# Altium schematic sheet Airlock_Motor.SchDoc(Airlock_Motor)
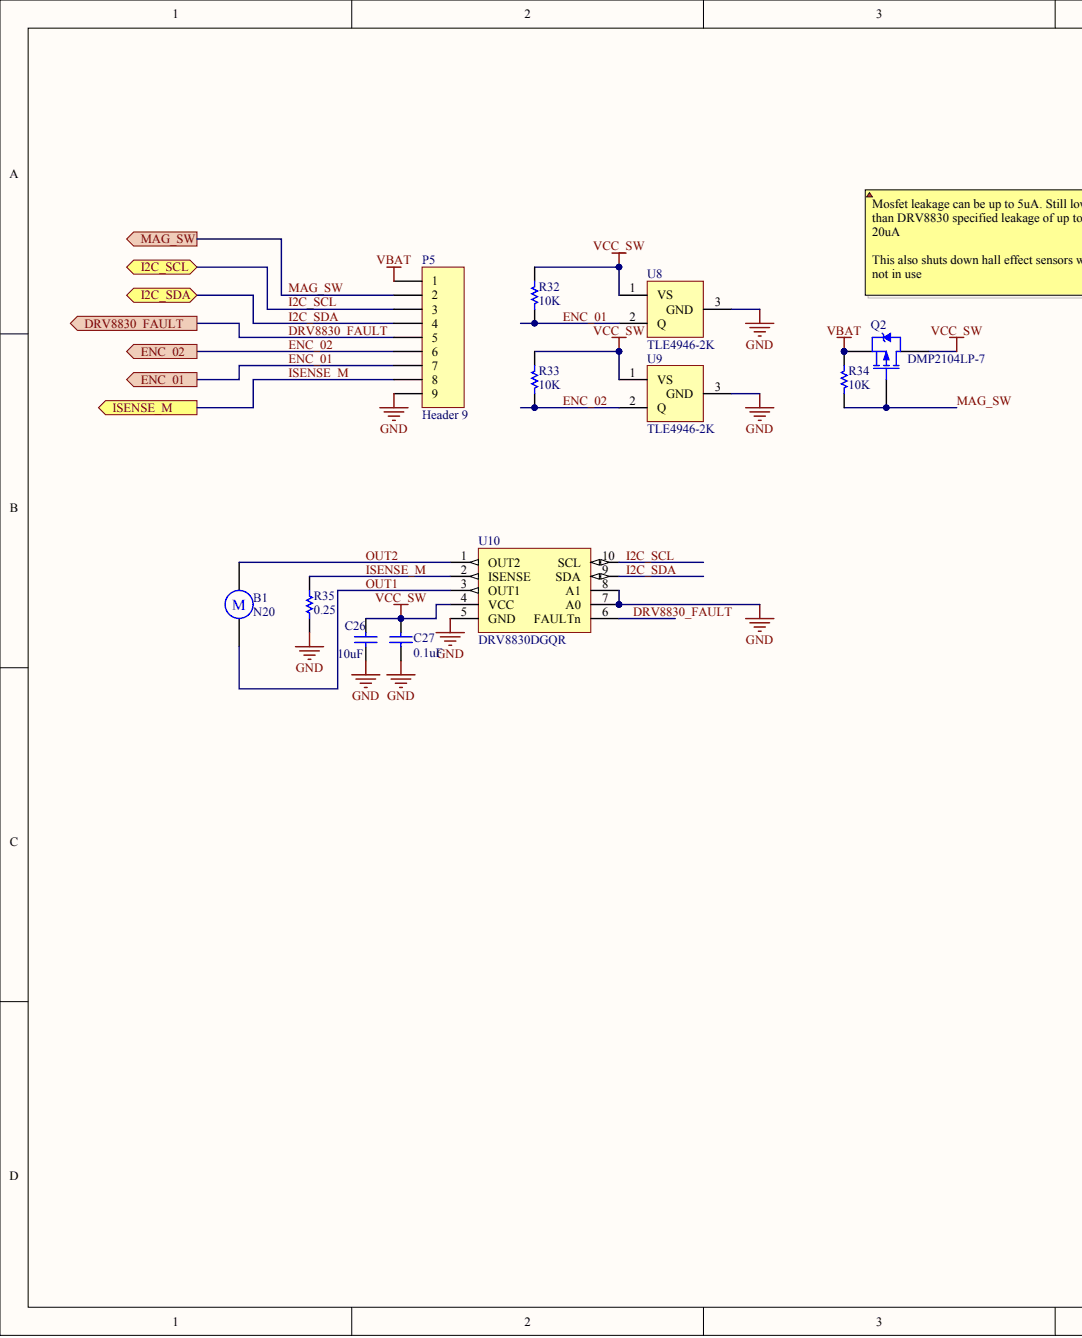
[diagram p0: Airlock_Motor.SchDoc, left]
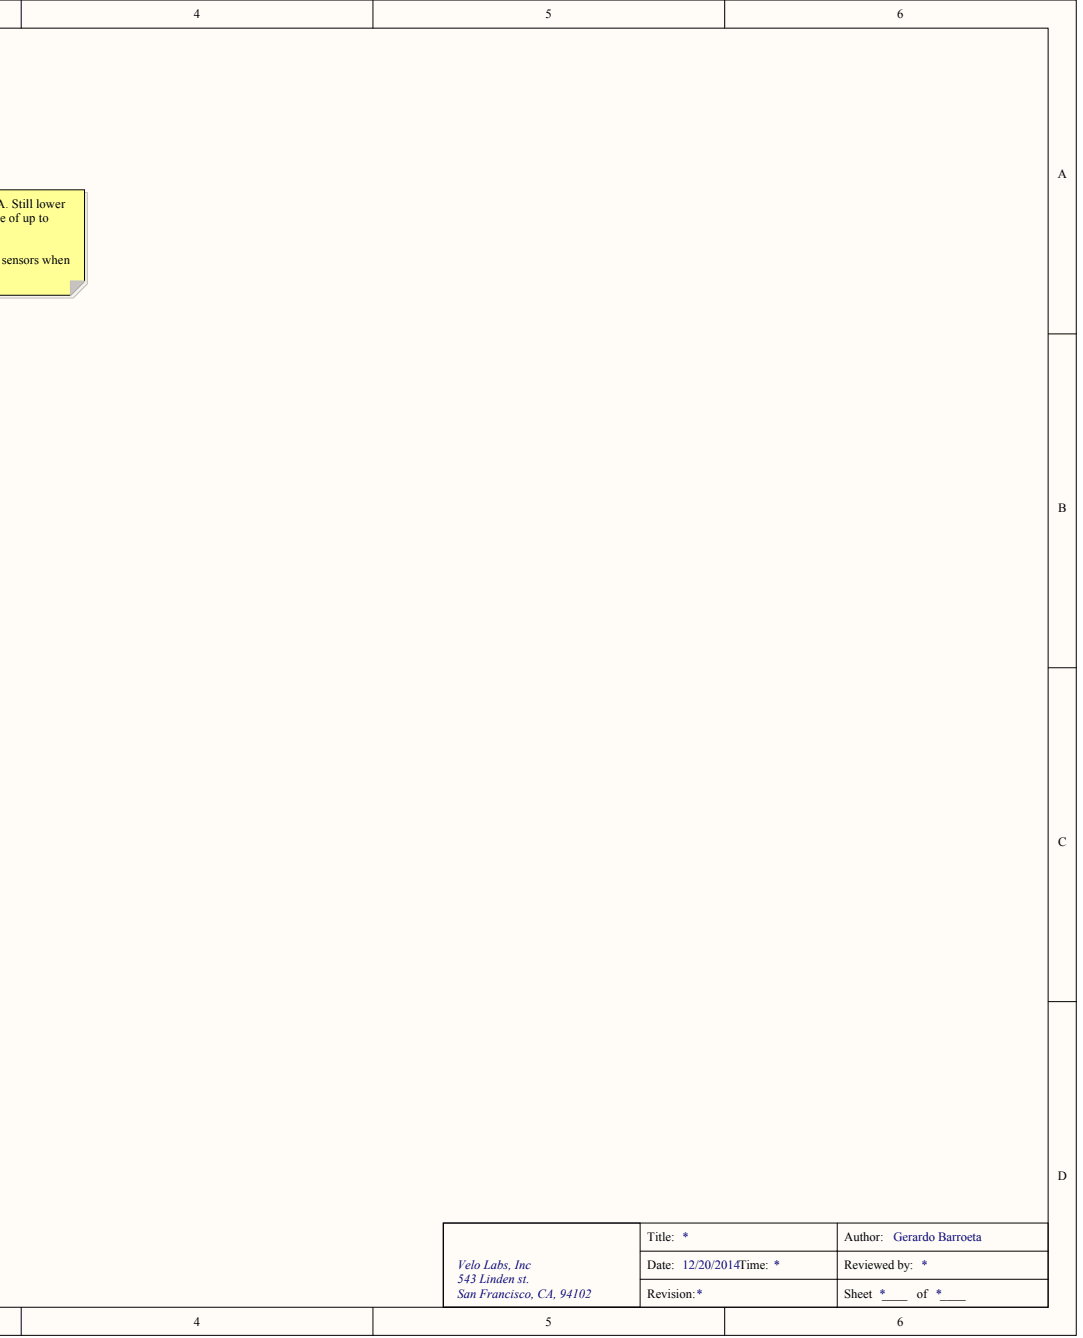
[diagram p1: Airlock_Motor.SchDoc, right]

## Parts
B1  N20
C26  value 10uF  (hidden comment: X5R, 6.3V, 20%)
C27  value 0.1uF  (hidden comment: X7R, 16V, 10%)
P5  Header 9
Q2  DMP2104LP-7
R32  1%  value 10K
R33  1%  value 10K
R34  1%  value 10K
R35  value 0.25  (hidden comment: 1%)
U8  TLE4946-2K
U9  TLE4946-2K
U10  DRV8830DGQR

## Ports
DRV8830_FAULT, ENC_01, ENC_02, I2C_SCL, I2C_SDA, ISENSE_M, MAG_SW

## Nets
GND: C26.1 C27.2 P5.9 R35.2 U8.3 U9.3 U10.0 U10.5(GND) U10.7(A0) U10.8(A1)
VBAT: P5.1 Q2.2 R34.2
VCC_SW: C26.2 C27.1 Q2.3 R32.2 R33.2 U8.1 U9.1 U10.4(VCC)
DRV8830_FAULT: P5.5 U10.6(FAULTn) ; port DRV8830_FAULT
ENC_01: P5.7 R32.1 U8.2 ; port ENC_01
ENC_02: P5.6 R33.1 U9.2 ; port ENC_02
I2C_SCL: P5.3 U10.10(SCL) ; port I2C_SCL
I2C_SDA: P5.4 U10.9(SDA) ; port I2C_SDA
ISENSE_M: P5.8 R35.1 U10.2(ISENSE) ; port ISENSE_M
MAG_SW: P5.2 Q2.1 R34.1 ; port MAG_SW
OUT1: B1.2 U10.3(OUT1)
OUT2: B1.1 U10.1(OUT2)

## Library data of the parts
C26 = (no symbol name): Manufacturer: Taiyo Yuden; Manufacturer Part Number: JMK107ABJ106MAHT; Capacitance: 10µF; Description 1: CAP CER 10UF 6.3V 20% X5R 0603; Supplier 1: Digi-Key; Supplier Part Number 1: 587-3383-1-ND; RoHS: RoHS Compliant; Category: Capacitors; Stock 1: 338432; Pricing 1: 1=0.32, 10=0.21, 50=0.109, 100=0.084, 250=0.06036, 500=0.04306, 1000=0.0315 (USD); Packaging: Cut Tape (CT); Tolerance: ±20%; Voltage - Rated: 6.3V; Temperature Coefficient: X5R; Mounting Type: Surface Mount, MLCC; Operating Temperature: -55°C ~ 85°C; Applications: Automotive; Ratings: AEC-Q200; Package / Case: 0603 (1608 Metric); Size / Dimension: 0.063" L x 0.031" W (1.60mm x 0.80mm); Height - Seated (Max): -; Thickness (Max): 0.035" (0.90mm); Lead Spacing: -; Features: -; Lead Style: -; ComponentLink1URL: http://www.yuden.co.jp/productdata/catalog/en/mlcc01_hq_e.pdf; ComponentLink1Description: http://www.yuden.co.jp/productdata/catalog/en/mlcc01_hq_e.pdf
C27 = (no symbol name): Manufacturer: Murata Electronics North America; Manufacturer Part Number: GRM155R71C104KA88D; Description 1: CAP CER 0.1UF 16V 10% X7R 0402; Capacitance: 0.1µF; Supplier 1: Digi-Key; Supplier Part Number 1: 490-3261-1-ND; RoHS: RoHS Compliant; Category: Capacitors; Stock 1: 16410340; Pricing 1: 1=0.1, 10=0.015, 50=0.0082, 100=0.0069, 250=0.00564, 500=0.00482, 1000=0.00375, 5000=0.00313 (USD); Packaging: Cut Tape (CT); Tolerance: ±10%; Voltage - Rated: 16V; Temperature Coefficient: X7R; Mounting Type: Surface Mount, MLCC; Operating Temperature: -55°C ~ 125°C; Applications: General Purpose; Ratings: -; Package / Case: 0402 (1005 Metric); Size / Dimension: 0.039" L x 0.020" W (1.00mm x 0.50mm); Height - Seated (Max): -; Thickness (Max): 0.022" (0.55mm); Lead Spacing: -; Features: -; Lead Style: -; ComponentLink1URL: http://www.murata.com/~/media/webrenewal/support/library/catalog/products/capacitor/mlcc/c02e.ashx; ComponentLink1Description: http://www.murata.com/~/media/webrenewal/support/library/catalog/products/capacitor/mlcc/c02e.ashx
Q2 = (no symbol name): Manufacturer: Diodes Inc; Description 1: MOSFET P-CH 20V 1.5A 3-DFN; FET Type: MOSFET P-Channel, Metal Oxide; FET Feature: Logic Level Gate; Drain to Source Voltage (Vdss): 20V; Current - Continuous Drain (Id) @ 25° C: 1.5A (Ta); Rds On (Max) @ Id, Vgs: 150 mOhm @ 950mA, 4.5V; Vgs(th) (Max) @ Id: 1V @ 250µA; Input Capacitance (Ciss) @ Vds: 320pF @ 16V; Power - Max: 500mW; Published: 8-Jun-2000; LatestRevisionDate: 17-Jul-2002; LatestRevisionNote: Re-released for DXP Platform.; PackageVersion: 1995/1996; PackageReference: E3; PackageDescription: TO, Flat Index; 3 In-Line, Axial Leads; Body Dia. 4.6mm; Lead Dia. 0.5 mm (max); Supplier 1: Digi-Key; Manufacturer Part Number: DMP2104LP-7; RoHS: RoHS Compliant; Category: Discrete Semiconductor Products; Stock 1: 8333; Pricing 1: 1=0.44, 10=0.341, 25=0.288, 100=0.2347, 250=0.19436, 500=0.16056, 1000=0.12025 (USD); Packaging: Digi-Reel®; Gate Charge (Qg) @ Vgs: -; Mounting Type: Surface Mount; Package / Case: 3-XDFN; Supplier Device Package: DFN1411-3; ComponentLink1URL: http://www.diodes.com/datasheets/ds31091.pdf; ComponentLink1Description: http://www.diodes.com/datasheets/ds31091.pdf; Supplier Part Number 1: DMP2104LPDIDKR-ND; Publisher: Altium Limited
R32-R34 = (no symbol name): Manufacturer: Panasonic Electronic Components; Manufacturer Part Number: ERJ-2RKF1002X; Description 1: RES SMD 10K OHM 1% 1/10W 0402; Power (Watts): 0.1W, 1/10W; Temperature Coefficient: ±100ppm/°C; Published: 8-Jun-2000; LatestRevisionDate: 17-Jul-2002; LatestRevisionNote: Re-released for DXP Platform.; PackageReference: AXIAL-0.3; PackageDescription: Axial Device, Thru-Hole; 2 Leads; 0.3 in Pin Spacing; Supplier 1: Digi-Key; Supplier Part Number 1: P10.0KLCT-ND; RoHS: RoHS Compliant; Category: Resistors; Stock 1: 185308; Pricing 1: 1=0.1, 50=0.0196, 100=0.0149, 250=0.01104, 500=0.00816, 1000=0.00544, 5000=0.00383 (USD); Packaging: Cut Tape (CT); Resistance (Ohms): 10k; Tolerance: ±1%; Composition: Thick Film; Features: Automotive AEC-Q200 Qualified; Operating Temperature: -55°C ~ 155°C; Package / Case: 0402 (1005 Metric); Supplier Device Package: 0402; Size / Dimension: 0.039" L x 0.020" W (1.00mm x 0.50mm); Height: 0.016" (0.40mm); Number of Terminations: 2; ComponentLink1URL: http://industrial.panasonic.com/www-cgi/jvcr13pz.cgi?E+PZ+3+AOA0002+ERJ2RKF1002X+7+WW; ComponentLink1Description: http://industrial.panasonic.com/www-cgi/jvcr13pz.cgi?E+PZ+3+AOA0002+ERJ2RKF1002X+7+WW; Publisher: Altium Limited
R35 = (no symbol name): Manufacturer: Stackpole Electronics Inc; Manufacturer Part Number: CSR0805FKR250; Description 1: RES SMD 0.25 OHM 1% 1/4W 0805; Power (Watts): 0.25W, 1/4W; Temperature Coefficient: ±200ppm/°C; Published: 8-Jun-2000; LatestRevisionDate: 17-Jul-2002; LatestRevisionNote: Re-released for DXP Platform.; PackageReference: AXIAL-0.3; PackageDescription: Axial Device, Thru-Hole; 2 Leads; 0.3 in Pin Spacing; Supplier 1: Digi-Key; Supplier Part Number 1: CSR0805FKR250CT-ND; RoHS: RoHS Compliant; Category: Resistors; Stock 1: 15888; Pricing 1: 1=0.56, 10=0.38, 50=0.23, 100=0.19, 250=0.15, 500=0.128 (USD); Packaging: Cut Tape (CT); Resistance (Ohms): 0.25; Tolerance: ±1%; Composition: Thick Film; Features: Current Sense; Operating Temperature: -55°C ~ 155°C; Package / Case: 0805 (2012 Metric); Supplier Device Package: 0805; Size / Dimension: 0.079" L x 0.049" W (2.00mm x 1.25mm); Height: 0.026" (0.65mm); Number of Terminations: 2; ComponentLink1URL: http://www.seielect.com/catalog/SEI-CSR_CSRN.pdf; ComponentLink1Description: http://www.seielect.com/catalog/SEI-CSR_CSRN.pdf; Publisher: Altium Limited
U8 U9 = (no symbol name): Manufacturer: Infineon Technologies; Description 1: IC HALL EFFECT LATCH SC59; Sensing Range: 2mT Trip, -2mT Release; Voltage - Supply: 2.7 V ~ 18 V; Current - Supply: 4mA; Current - Output (Max): 20mA; Output Type: Digital, Open Collector; Supplier 1: Digi-Key; Manufacturer Part Number: TLE4946-2K; RoHS: RoHS Compliant; Category: Sensors, Transducers; Stock 1: 7162; Pricing 1: 1=1.1, 5=1.042, 10=0.908, 25=0.7976, 50=0.6624, 100=0.5643, 250=0.51528, 500=0.49074, 1000=0.42939 (USD); Packaging: Cut Tape (CT); Type: Bipolar Latch; Features: Regulated Voltage; Operating Temperature: -40°C ~ 150°C; Package / Case: TO-236-3, SC-59, SOT-23-3; Supplier Device Package: SC-59; ComponentLink1URL: http://www.infineon.com/dgdl/TLE4946-2K_DS_Rev1.0.pdf?folderId=db3a30431f848401011facc1c83b4674&fileId=db3a30431f848401011fbc925c48637f; ComponentLink1Description: http://www.infineon.com/dgdl/TLE4946-2K_DS_Rev1.0.pdf?folderId=db3a30431f848401011facc1c83b4674&fileId=db3a30431f848401011fbc925c48637f; Supplier Part Number 1: TLE4946-2KCT-ND
U10 = (no symbol name): Manufacturer: Texas Instruments; Description 1: IC MOTOR DRIVER I2C 10MSOP; Motor Type - Stepper: Bipolar; Motor Type - AC, DC: Brushed DC, PMSM; Function: Driver - Fully Integrated, Control and Power Stage; Output Configuration: Full H-Bridge, (1) Single; Interface: I2C; Current - Output: 1A; Voltage - Supply: 2.75 V ~ 6.8 V; Supplier 1: Digi-Key; Manufacturer Part Number: DRV8830DGQR; RoHS: RoHS Compliant; Category: Integrated Circuits (ICs); Stock 1: 5336; Pricing 1: 1=2.44, 10=2.203, 25=1.9736, 100=1.0328, 250=1.01364, 500=0.9945, 750=0.97537 (USD); Packaging: Cut Tape (CT); Applications: Battery Powered; Voltage - Load: 2.75 V ~ 6.8 V; Operating Temperature: -40°C ~ 85°C; Mounting Type: Surface Mount; Package / Case: 10-TFSOP, 10-MSOP (0.118", 3.00mm Width) Exposed Pad; Supplier Device Package: 10-MSOP-PowerPad; ComponentLink1URL: http://www.ti.com/lit/ds/symlink/drv8830.pdf; ComponentLink1Description: http://www.ti.com/lit/ds/symlink/drv8830.pdf; Supplier Part Number 1: 296-28165-1-ND
B1 P5 = (no symbol name): LatestRevisionDate: 17-Jul-2002; LatestRevisionNote: Re-released for DXP Platform.; Publisher: Altium Limited
  B1: Published: 24-Mar-1999; PackageReference: RB5-10.5; PackageDescription: Capacitor (Radial); 2 Leads
